# Altium schematic sheet Status Indication and UART Level Shifting.SchDoc("Status Indication and UART Level Shifting")
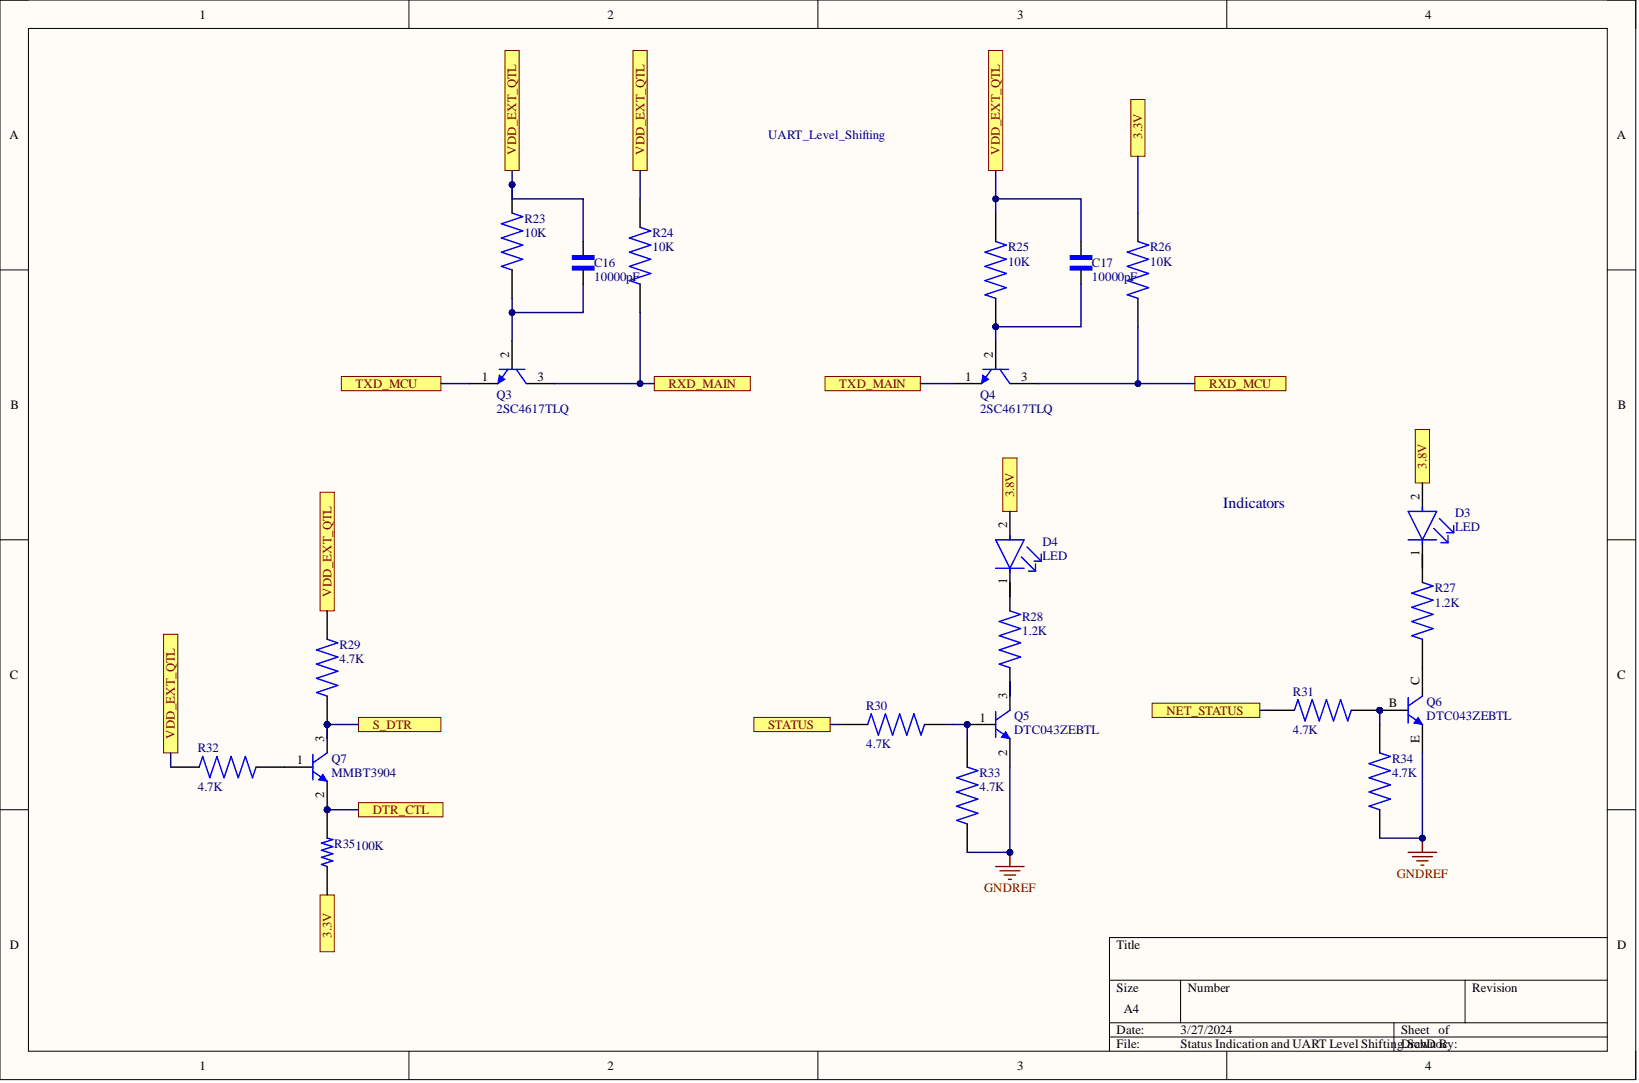
[diagram p0: Status Indication and UART Level Shifting.SchDoc]

## Parts
C16  10000pF
C17  10000pF
D3  LED
D4  LED
Q3  2SC4617TLQ
Q4  2SC4617TLQ
Q5  DTC043ZEBTL
Q6  DTC043ZEBTL
Q7  MMBT3904
R23  10K
R24  10K
R25  10K
R26  10K
R27  1.2K
R28  1.2K
R29  4.7K
R30  4.7K
R31  4.7K
R32  4.7K
R33  4.7K
R34  4.7K
R35  100K

## Ports
3.3V, 3.8V, DTR_CTL, NET_STATUS, RXD_MAIN, RXD_MCU, S_DTR, STATUS, TXD_MAIN, TXD_MCU, VDD_EXT_QTL

## Nets
GNDREF: Q5.2 Q6.E R33.1 R34.1
3.3V: R26.2 R35.1 ; port 3.3V
3.8V: D3.2 D4.2 ; port 3.8V
DTR_CTL: Q7.2 R35.2 ; port DTR_CTL
NET_STATUS: R31.2 ; port NET_STATUS
RXD_MAIN: Q3.3 R24.1 ; port RXD_MAIN
RXD_MCU: Q4.3 R26.1 ; port RXD_MCU
S_DTR: Q7.3 R29.1 ; port S_DTR
STATUS: R30.2 ; port STATUS
TXD_MAIN: Q4.1 ; port TXD_MAIN
TXD_MCU: Q3.1 ; port TXD_MCU
VDD_EXT_QTL: C16.2 C17.2 R23.2 R24.2 R25.2 R29.2 R32.2 ; port VDD_EXT_QTL
NetC16_1: C16.1 Q3.2 R23.1
NetC17_1: C17.1 Q4.2 R25.1
NetQ5_1: Q5.1 R30.1 R33.2
NetQ5_3: Q5.3 R28.1
NetQ6_B: Q6.B R31.1 R34.2
NetQ6_C: Q6.C R27.1
NetQ7_1: Q7.1 R32.1

Not connected: D3 1; D4 1; R27 2; R28 2

## Library data of the parts
C16 C17 = GCM188R72A103KA37D: .01UF 100V 10% 0603; MF: {'manufacturer': u'Murata'}; Availability: Unavailable; Component Kind: Standard; MP: GCM188R72A103KA37D; Package: None; Price: None
D3 D4 = Component_1: Component Kind: Standard; Library Name: LED.SchLib
Q3-Q7 = CMP-2000-05934-1: NPN Small Signal Transistor, 45 V, 500 mA, -65 to 150 degC, 3-Pin SOT323, RoHS, Tape and Reel; Brand: DiodesIncorporated; Collector- Base Voltage VCBO: 50V; Collector- Emitter Voltage VCEO Max: 45V; Collector-Emitter Saturation Voltage: 0.7V; Configuration: Single; DC Collector/Base Gain hfe Min: 250; Emitter- Base Voltage VEBO: 5V; Gain Bandwidth Product fT: 100MHz; Maximum DC Collector Current: 1A; Pd - Power Dissipation: 200mW; Unit Weight: 0.000282oz; Component Kind: Standard; Datasheet URL: http://www.diodes.com/_files/datasheets/ds30575.pdf; Datasheet Version: Rev. 5-2, 01/2014; Factory Pack Quantity: 3000; Height: 1mm; Length: 2.2mm; Library Name: DTC043ZEBTL.SchLib; Manufacturer: DiodesIncorporated; Manufacturer URL: http://www.diodes.com/; Maximum Operating Temperature: +150C; Minimum Operating Temperature: -65C; Mounting Style: SMD/SMT; Mounting Technology: SurfaceMount; Package / Case: SOT-23; Package Description: 3-Pin Small Outline Transistor, Body 2 x 1.25 mm, Pitch 0.65 mm; Package Reference: SOT323; Packaging: Reel; Product Category: BipolarTransistors-BJT; RoHS: True; Series: BC817; Transistor Polarity: NPN; Width: 1.35mm
R23-R26 = RC0603FR-0710KL: YAGEO RC0603FR-0710KL / RESISTOR 10K 1/10W 1% 0603 YAG <a href="https://pricing.snapeda.com/parts/RC0603FR-0710KL/Yageo/view-part?ref=eda">Check availability</a>; MF: {'manufacturer': u'Yageo'}; Availability: Unavailable; Component Kind: Standard; MP: RC0603FR-0710KL; Package: None; Price: None
R27 R28 = RC0603JR-071K2L: RES, THICK FILM, 1K2, 5%, 0.1W, 0603; MF: {'manufacturer': u'Yageo'}; Availability: Unavailable; Component Kind: Standard; MP: RC0603JR-071K2L; Package: None; Price: None
R29-R34 = RC0402FR-074K7L: <a href="https://pricing.snapeda.com/parts/RC0402FR-074K7L/Yageo/view-part?ref=eda">Check availability</a>; MF: {'manufacturer': u'Yageo'}; Availability: Unavailable; Component Kind: Standard; MP: RC0402FR-074K7L; Package: None; Price: None
R35 = RC0603FR-07100KL: RC Series 0603 0.1 W 100 kOhms 1% Â±100 ppm/Â°C SMT Thick Film Chip Resistor; MF: {'manufacturer': u'Yageo'}; Availability: Unavailable; Component Kind: Standard; MP: RC0603FR-07100KL; Package: None; Price: None
